# Altium schematic sheet IO.SchDoc(IO)
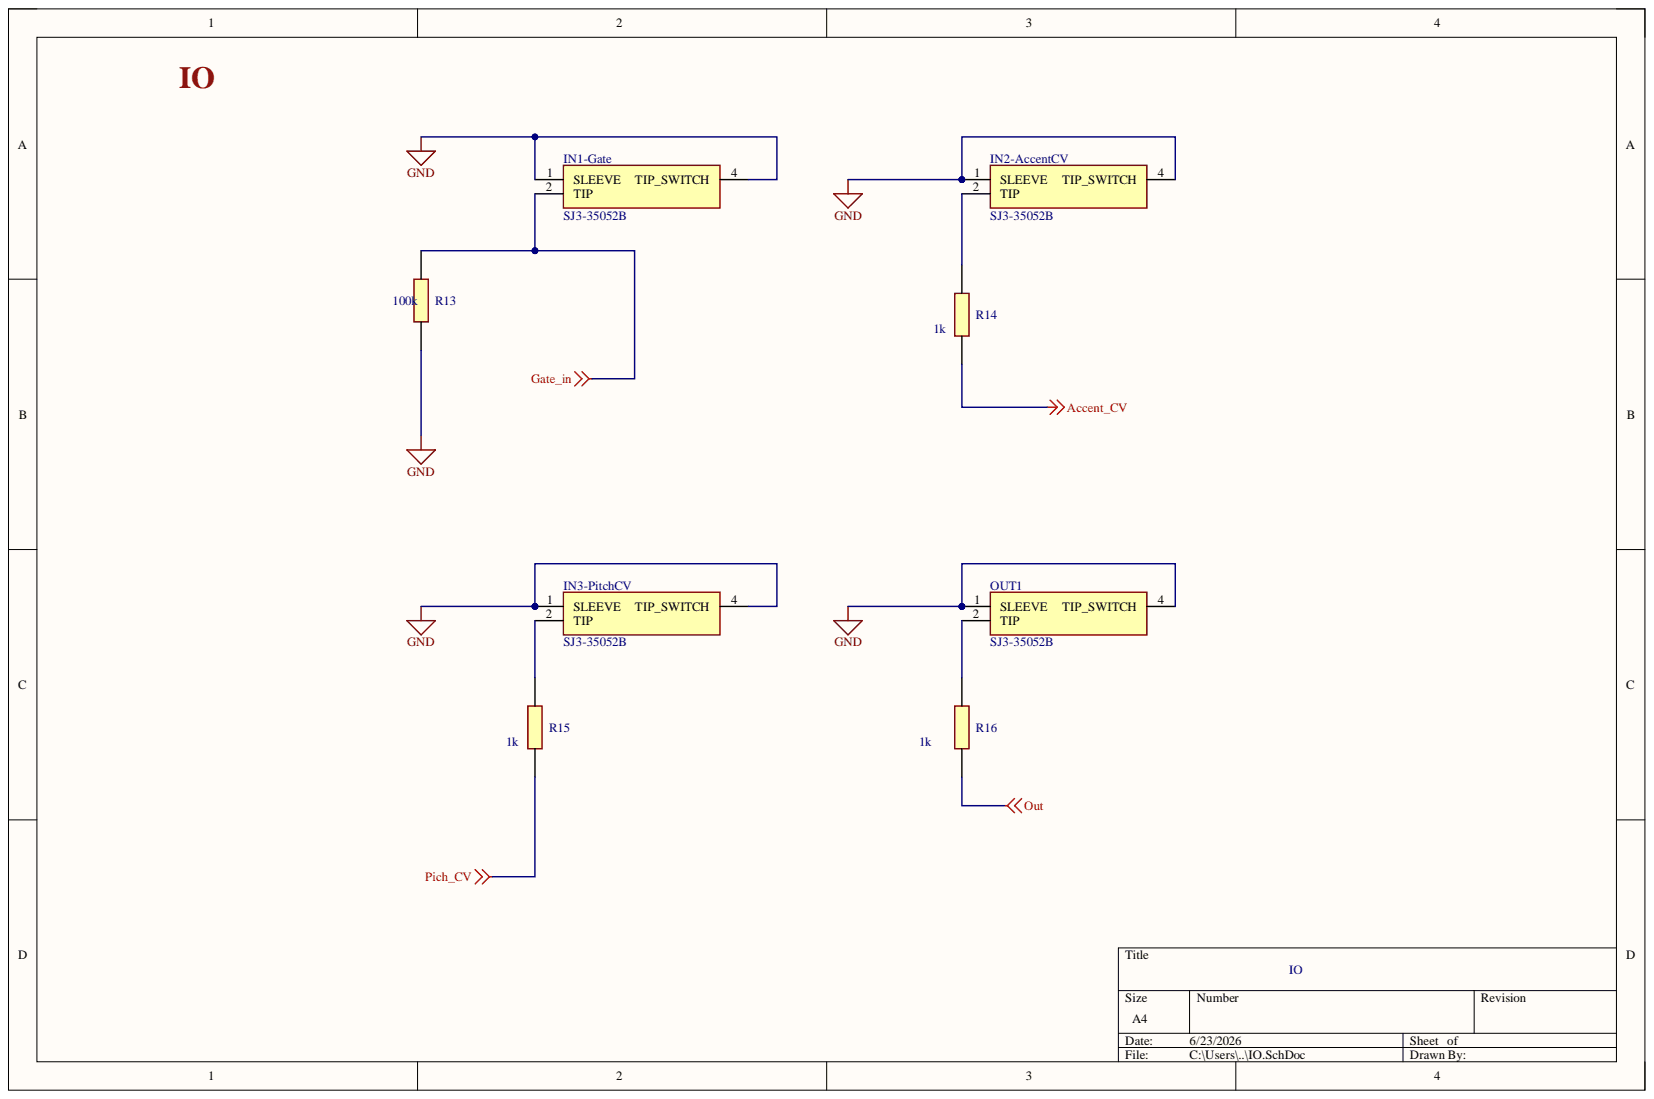
[diagram p0: IO.SchDoc]

## Parts
IN1-Gate  SJ3-35052B  [SJ335052B]
IN2-AccentCV  SJ3-35052B  [SJ335052B]
IN3-PitchCV  SJ3-35052B  [SJ335052B]
OUT1  SJ3-35052B  [SJ335052B]
R13  value 100k  [RESAD1590W60L630D240]  (hidden comment: MFR-25FTF52-600K)
R14  value 1k  [RESAD1590W60L630D240]  (hidden comment: MFR-25FTF52-600K)
R15  value 1k  [RESAD1590W60L630D240]  (hidden comment: MFR-25FTF52-600K)
R16  value 1k  [RESAD1590W60L630D240]  (hidden comment: MFR-25FTF52-600K)

## Nets
Accent_CV: R14.1
Gate_in: IN1-Gate.2 R13.1
GND: IN1-Gate.1 IN1-Gate.4 IN2-AccentCV.1 IN2-AccentCV.4 IN3-PitchCV.1 IN3-PitchCV.4 OUT1.1 OUT1.4 R13.2
Out: R16.1
Pich_CV: R15.1
NetIN2-AccentCV_2: IN2-AccentCV.2 R14.2
NetIN3-PitchCV_2: IN3-PitchCV.2 R15.2
NetOUT1_2: OUT1.2 R16.2

## Library data of the parts
IN1-Gate IN2-AccentCV IN3-PitchCV OUT1 = CMP-032-000001-1: 3.5 mm Stereo Jack; Manufacturer_Name: Same Sky; Component Kind: Standard; Datasheet Link: https://www.sameskydevices.com/product/resource/supplyframepdf/sj3-3505x.pdf; Design Item ID: SJ3-35052B; Height: 17.75mm; Manufacturer_Part_Number: SJ3-35052B; Mouser Part Number: 179-SJ3-35052B; Mouser Price/Stock: https://www.mouser.co.uk/ProductDetail/Same-Sky/SJ3-35052B?qs=IKkN%2F947nfDmh7CCP4LptQ%3D%3D; Source: SamacSys.SchLib; Library Name: PoliTeK
R13-R16 = CMP-009-00182-2: Metal Film Resistors - Through Hole; Manufacturer_Name: YAGEO; Component Kind: Standard; Component Type: Standard; Datasheet Link: https://www.mouser.sg/datasheet/2/447/YAGEO_MFR_datasheet_2023v3-3324391.pdf; Design Item ID: MFR-25FTF52-600K; Manufacturer_Part_Number: MFR-25FTF52-600K; Mouser Part Number: 603-MFR-25FTF52-600K; Mouser Price/Stock: https://www.mouser.co.uk/ProductDetail/YAGEO/MFR-25FTF52-600K?qs=xZ%2FP%252Ba9zWqYtTZdHR75SUg%3D%3D; Pins: 2; Source: SamacSys.SchLib; Library Name: EMEA - Politecnico di Torino - PoliTek Music
